# Altium schematic sheet Power.SchDoc(Power)
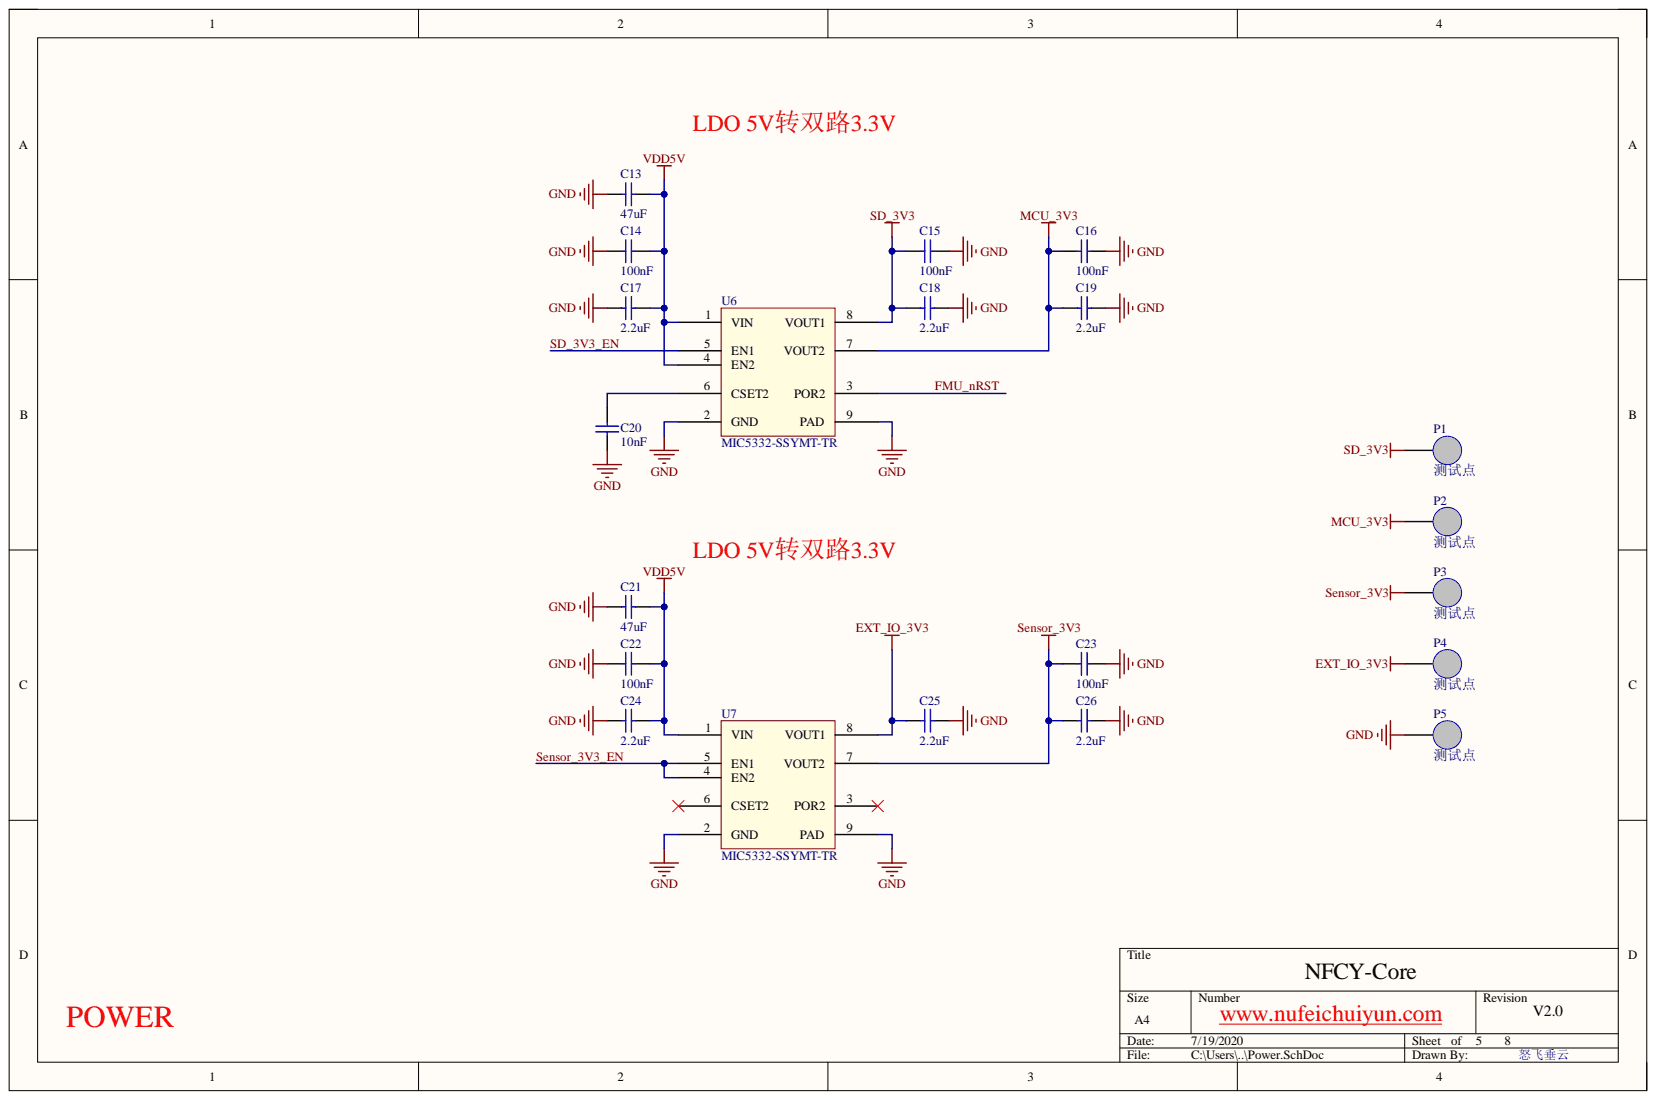
[diagram p0: Power.SchDoc]

## Parts
C13  value 47uF  [CAP0805]  (hidden comment: GRM21BR61A476ME15L)
C14  value 100nF  [CAP0402]  (hidden comment: CL05B104KO5NNNC)
C15  value 100nF  [CAP0402]  (hidden comment: CL05B104KO5NNNC)
C16  value 100nF  [CAP0402]  (hidden comment: CL05B104KO5NNNC)
C17  value 2.2uF  [CAP0402]  (hidden comment: CL05A225KP5NSNC)
C18  value 2.2uF  [CAP0402]  (hidden comment: CL05A225KP5NSNC)
C19  value 2.2uF  [CAP0402]  (hidden comment: CL05A225KP5NSNC)
C20  value 10nF  [CAP0402]  (hidden comment: CC0402KRX7R8BB103)
C21  value 47uF  [CAP0805]  (hidden comment: GRM21BR61A476ME15L)
C22  value 100nF  [CAP0402]  (hidden comment: CL05B104KO5NNNC)
C23  value 100nF  [CAP0402]  (hidden comment: CL05B104KO5NNNC)
C24  value 2.2uF  [CAP0402]  (hidden comment: CL05A225KP5NSNC)
C25  value 2.2uF  [CAP0402]  (hidden comment: CL05A225KP5NSNC)
C26  value 2.2uF  [CAP0402]  (hidden comment: CL05A225KP5NSNC)
P1  测试点  [PAD0p8MM]  (no BOM)
P2  测试点  [PAD0p8MM]  (no BOM)
P3  测试点  [PAD0p8MM]  (no BOM)
P4  测试点  [PAD0p8MM]  (no BOM)
P5  测试点  [PAD0p8MM]  (no BOM)
U6  MIC5332-SSYMT-TR  [MLF-8]
U7  MIC5332-SSYMT-TR  [MLF-8]

## Nets
EXT_IO_3V3: C25.2 P4.1 U7.8(VOUT1)
GND: C13.1 C14.2 C15.1 C16.1 C17.2 C18.1 C19.1 C20.2 C21.2 C22.2 C23.1 C24.2 C25.1 C26.1 P5.1 U6.2(GND) U6.9(PAD) U7.2(GND) U7.9(PAD)
MCU_3V3: C16.2 C19.2 P2.1 U6.7(VOUT2)
SD_3V3: C15.2 C18.2 P1.1 U6.8(VOUT1)
Sensor_3V3: C23.2 C26.2 P3.1 U7.7(VOUT2)
VDD5V: C13.2 C14.1 C17.1 C21.1 C22.1 C24.1 U6.1(VIN) U6.4(EN2) U7.1(VIN)
FMU_nRST: U6.3(POR2)
SD_3V3_EN: U6.5(EN1)
Sensor_3V3_EN: U7.4(EN2) U7.5(EN1)
NetC20_1: C20.1 U6.6(CSET2)

Not connected: U7 3(POR2) 6(CSET2)

## Library data of the parts
C13 C21 = Cap: 贴片电容; manufacturer: muRata(村田); Component Kind: Standard; LatestRevisionDate: 17-Jul-2002; LatestRevisionNote: Re-released for DXP Platform.; Library Name: Miscellaneous Devices.IntLib; PackageDescription: Radial Cap, Thru-Hole; 2 Leads; 0.3 in Pin Spacing; PackageReference: RAD-0.3; Published: 8-Jun-2000; Signal Integrity: Cap; Simulation: CAP; Publisher: Altium Limited
C14-C19 C22-C26 = Cap: 贴片电容; manufacturer: SAMSUNG(三星); Component Kind: Standard; LatestRevisionDate: 17-Jul-2002; LatestRevisionNote: Re-released for DXP Platform.; Library Name: Miscellaneous Devices.IntLib; PackageDescription: Radial Cap, Thru-Hole; 2 Leads; 0.3 in Pin Spacing; PackageReference: RAD-0.3; Published: 8-Jun-2000; Signal Integrity: Cap; Simulation: CAP; Publisher: Altium Limited
C20 = Cap: 贴片电容; manufacturer: YAGEO(国巨); Component Kind: Standard; LatestRevisionDate: 17-Jul-2002; LatestRevisionNote: Re-released for DXP Platform.; Library Name: Miscellaneous Devices.IntLib; PackageDescription: Radial Cap, Thru-Hole; 2 Leads; 0.3 in Pin Spacing; PackageReference: RAD-0.3; Published: 8-Jun-2000; Signal Integrity: Cap; Simulation: CAP; Publisher: Altium Limited
P1-P5 = TestPad: Library Name: TestPad.SchLib
U6 U7 = MIC5332-SSYMT-TR: 双路LDO; manufacturer: Micrel; Component Kind: Standard; Library Name: Chip.SchLib
